# Altium schematic sheet 01_Measure.SchDoc
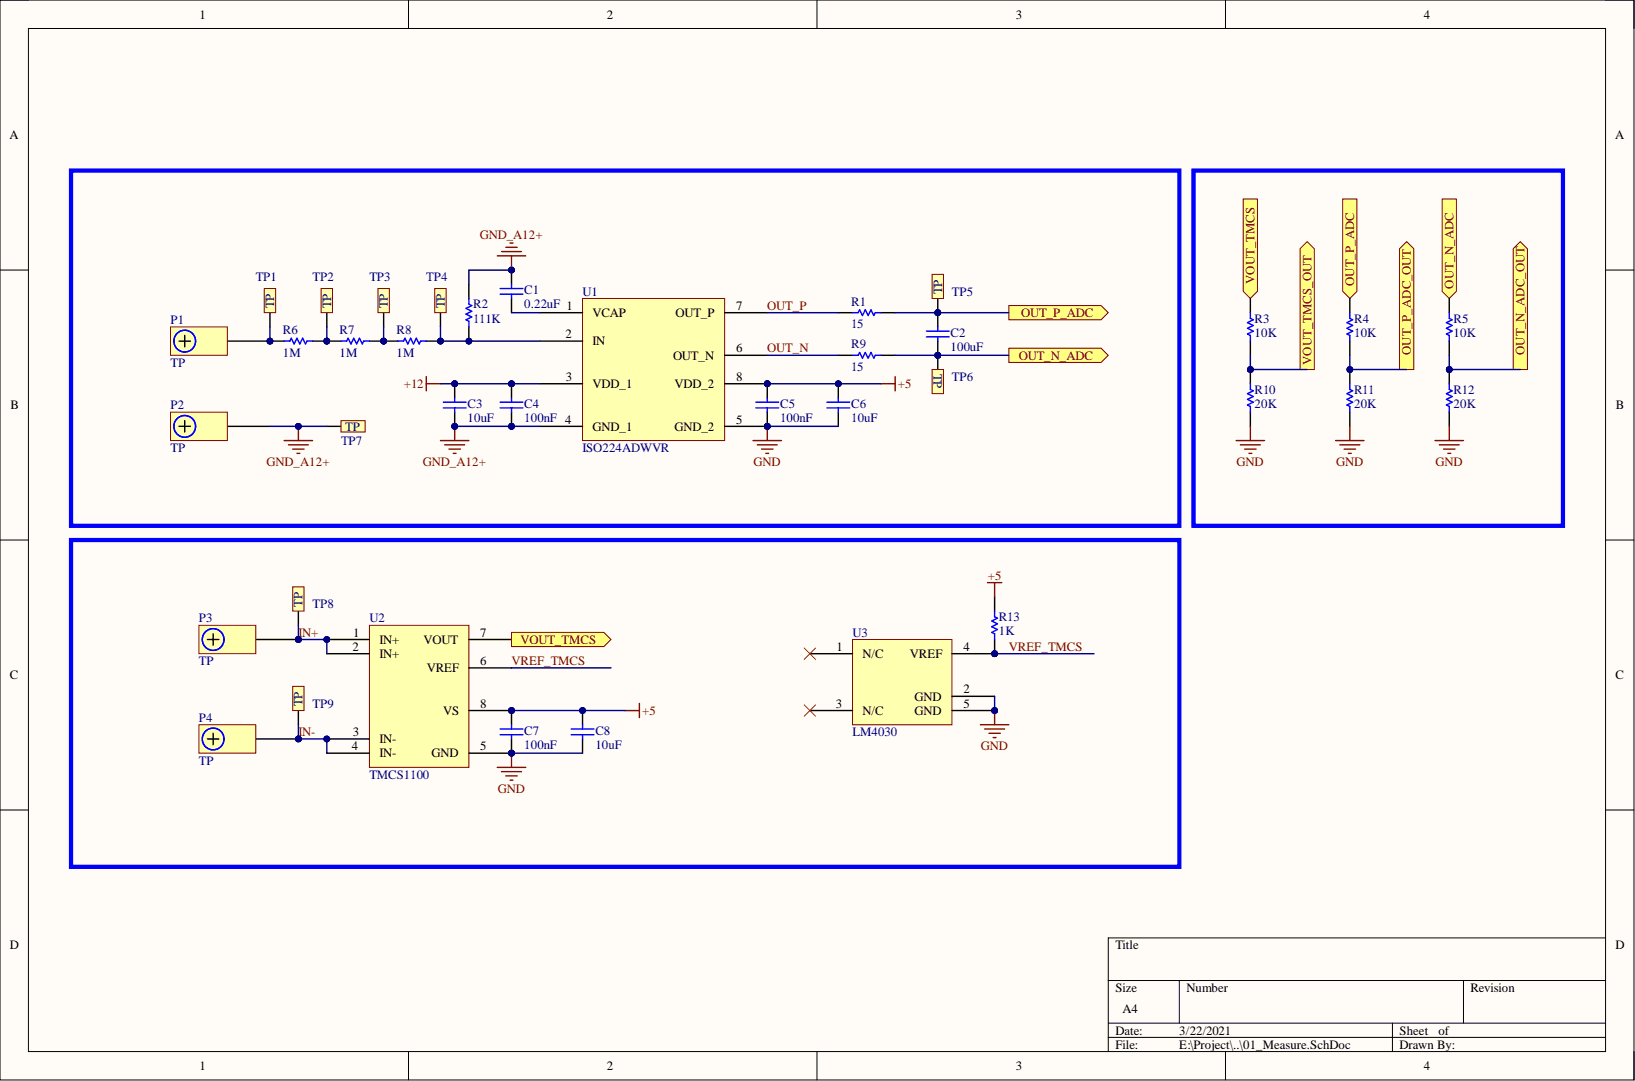
[diagram p0: 01_Measure.SchDoc]

## Parts
C1  value 0.22uF  [CAP-SM-0805]  (hidden comment: Cap Non-Pol SMD)
C2  value 100uF  [CAP-SM-1210]  (hidden comment: Cap Non-Pol SMD)
C3  value 10uF  [CAP-SM-0805]  (hidden comment: Cap Non-Pol SMD)
C4  value 100nF  [CAP-SM-0805]  (hidden comment: Cap Non-Pol SMD)
C5  value 100nF  [CAP-SM-0805]  (hidden comment: Cap Non-Pol SMD)
C6  value 10uF  [CAP-SM-0805]  (hidden comment: Cap Non-Pol SMD)
C7  value 100nF  [CAP-SM-0805]  (hidden comment: Cap Non-Pol SMD)
C8  value 10uF  [CAP-SM-0805]  (hidden comment: Cap Non-Pol SMD)
P1  TP  [TP]
P2  TP  [TP]
P3  TP  [TP]
P4  TP  [TP]
R1  value 15  [RES-SM-1206]  (hidden comment: RESISTOR SMD)
R2  value 111K  [RES-SM-1206]  (hidden comment: RESISTOR SMD)
R3  value 10K  [RES-SM-1206]  (hidden comment: RESISTOR SMD)
R4  value 10K  [RES-SM-1206]  (hidden comment: RESISTOR SMD)
R5  value 10K  [RES-SM-1206]  (hidden comment: RESISTOR SMD)
R6  value 1M  [RES-SM-1206]  (hidden comment: RESISTOR SMD)
R7  value 1M  [RES-SM-1206]  (hidden comment: RESISTOR SMD)
R8  value 1M  [RES-SM-1206]  (hidden comment: RESISTOR SMD)
R9  value 15  [RES-SM-1206]  (hidden comment: RESISTOR SMD)
R10  value 20K  [RES-SM-1206]  (hidden comment: RESISTOR SMD)
R11  value 20K  [RES-SM-1206]  (hidden comment: RESISTOR SMD)
R12  value 20K  [RES-SM-1206]  (hidden comment: RESISTOR SMD)
R13  value 1K  [RES-SM-0603]  (hidden comment: RESISTOR SMD)
TP1  Test Point  [TP]  (value not drawn)
TP2  Test Point  [TP]  (value not drawn)
TP3  Test Point  [TP]  (value not drawn)
TP4  Test Point  [TP]  (value not drawn)
TP5  Test Point  [TP]  (value not drawn)
TP6  Test Point  [TP]  (value not drawn)
TP7  Test Point  [TP]  (value not drawn)
TP8  Test Point  [TP]  (value not drawn)
TP9  Test Point  [TP]  (value not drawn)
U1  ISO224ADWVR  [SOIC127P1150X280-8N]
U2  TMCS1100  [SOIC127P650X175-8N]
U3  LM4030  [SOT95P279X130-5N]

## Ports
OUT_N_ADC, OUT_N_ADC_OUT, OUT_P_ADC, OUT_P_ADC_OUT, VOUT_TMCS, VOUT_TMCS_OUT

## Nets
+5: C5.2 C6.2 C7.2 C8.2 R13.2 U1.8(VDD_2) U2.8(VS)
+12: C3.2 C4.2 U1.3(VDD_1)
GND: C5.1 C6.1 C7.1 C8.1 R10.1 R11.1 R12.1 U1.5(GND_2) U2.5(GND) U3.2(GND) U3.5(GND)
GND_A12+: C1.2 C3.1 C4.1 P2.1 R2.2 TP7.TP1 U1.4(GND_1)
IN+: P3.1 TP8.TP1 U2.1(IN+) U2.2(IN+)
IN-: P4.1 TP9.TP1 U2.3(IN-) U2.4(IN-)
OUT_N: R9.1 U1.6(OUT_N)
OUT_N_ADC: C2.1 R5.2 R9.2 TP6.TP1 ; port OUT_N_ADC
OUT_N_ADC_OUT: R5.1 R12.2 ; port OUT_N_ADC_OUT
OUT_P: R1.1 U1.7(OUT_P)
OUT_P_ADC: C2.2 R1.2 R4.2 TP5.TP1 ; port OUT_P_ADC
OUT_P_ADC_OUT: R4.1 R11.2 ; port OUT_P_ADC_OUT
VOUT_TMCS: R3.2 U2.7(VOUT) ; port VOUT_TMCS
VOUT_TMCS_OUT: R3.1 R10.2 ; port VOUT_TMCS_OUT
VREF_TMCS: R13.1 U2.6(VREF) U3.4(VREF)
NetC1_1: C1.1 U1.1(VCAP)
NetP1_1: P1.1 R6.1 TP1.TP1
NetR2_1: R2.1 R8.2 TP4.TP1 U1.2(IN)
NetR6_2: R6.2 R7.1 TP2.TP1
NetR7_2: R7.2 R8.1 TP3.TP1

Not connected: U3 1(N/C) 3(N/C)

## Library data of the parts
C1-C8 = Cap Non-Pol SMD: Capacitor; Component Kind: Standard; Library Name: PIF_Library.IntLib
R1-R13 = RESISTOR SMD: Resistor; Component Kind: Standard; Library Name: PIF_Library.IntLib
U1 = ISO224ADWVR: Manufacturer: Texas Instruments; Component Kind: Standard; ComponentLink1Description: Supplier 1 Link; ComponentLink1URL: https://www.mouser.vn/ProductDetail/Texas-Instruments/ISO224ADWV?qs=%252BEew9%252B0nqrAIOtaYYgHDyw%3D%3D; Manufacturer P/N: ISO224ADWV; Supplier 1: Mouser; Supplier 1 P/N: 595-ISO224ADWV; Library Name: MiclsLib.SchLib
U2 = TMCS1100: Manufacturer: Texas Instruments; Manufacturer P/N: TMCS1100A1QD; Component Kind: Standard; ComponentLink1Description: Supplier 1 Link; ComponentLink1URL: https://www.mouser.vn/ProductDetail/Texas-Instruments/TMCS1100A1QDR?qs=sPbYRqrBIVm7HRwTfsNKdw%3D%3D; Supplier 1: Mouser; Supplier 1 P/N: 595-TMCS1100A1QDR; Library Name: MiclsLib.IntLib
U3 = LM4030: Manufacturer: Texas Instruments; Manufacturer P/N: LM4030BMF-2.5/NOPB; Component Kind: Standard; ComponentLink1Description: Supplier 1 Link; ComponentLink1URL: https://www.mouser.vn/ProductDetail/Texas-Instruments/LM4030BMF-25-NOPB?qs=QbsRYf82W3GjKEoF5S%2FJEg%3D%3D; Supplier 1: Mouser; Supplier 1 P/N: 926-LM4030BMF25NOPB; Library Name: MiclsLib.IntLib
P1-P4 = TP: Component Kind: Standard; Library Name: MiclsLib.IntLib
TP1-TP9 = Test Point: Component Kind: Standard; Library Name: PIF_Library.IntLib
